# Altium schematic sheet LEDBoard.SchDoc(LEDBoard)
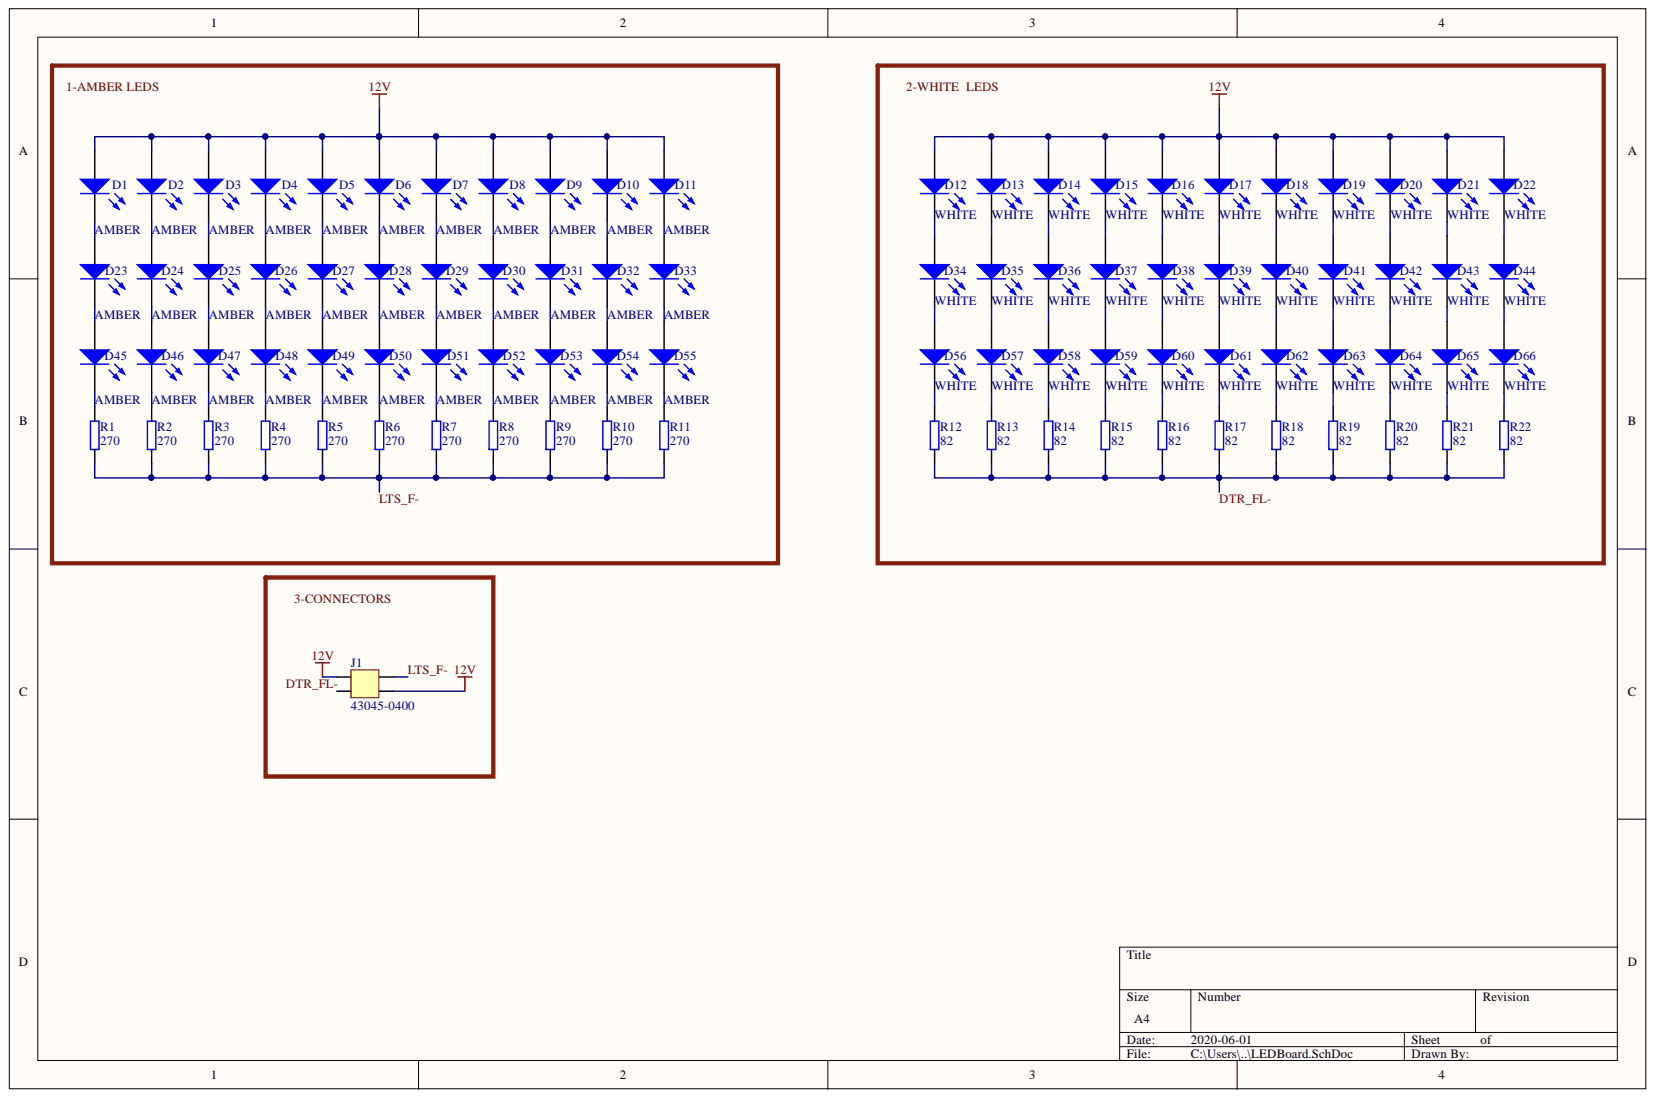
[diagram p0: LEDBoard.SchDoc]

## Parts
D1  AMBER  [XPCAMB-L1-0000-00501]
D2  AMBER  [XPCAMB-L1-0000-00501]
D3  AMBER  [XPCAMB-L1-0000-00501]
D4  AMBER  [XPCAMB-L1-0000-00501]
D5  AMBER  [XPCAMB-L1-0000-00501]
D6  AMBER  [XPCAMB-L1-0000-00501]
D7  AMBER  [XPCAMB-L1-0000-00501]
D8  AMBER  [XPCAMB-L1-0000-00501]
D9  AMBER  [XPCAMB-L1-0000-00501]
D10  AMBER  [XPCAMB-L1-0000-00501]
D11  AMBER  [XPCAMB-L1-0000-00501]
D12  WHITE  [XPCAMB-L1-0000-00501]
D13  WHITE  [XPCAMB-L1-0000-00501]
D14  WHITE  [XPCAMB-L1-0000-00501]
D15  WHITE  [XPCAMB-L1-0000-00501]
D16  WHITE  [XPCAMB-L1-0000-00501]
D17  WHITE  [XPCAMB-L1-0000-00501]
D18  WHITE  [XPCAMB-L1-0000-00501]
D19  WHITE  [XPCAMB-L1-0000-00501]
D20  WHITE  [XPCAMB-L1-0000-00501]
D21  WHITE  [XPCAMB-L1-0000-00501]
D22  WHITE  [XPCAMB-L1-0000-00501]
D23  AMBER  [XPCAMB-L1-0000-00501]
D24  AMBER  [XPCAMB-L1-0000-00501]
D25  AMBER  [XPCAMB-L1-0000-00501]
D26  AMBER  [XPCAMB-L1-0000-00501]
D27  AMBER  [XPCAMB-L1-0000-00501]
D28  AMBER  [XPCAMB-L1-0000-00501]
D29  AMBER  [XPCAMB-L1-0000-00501]
D30  AMBER  [XPCAMB-L1-0000-00501]
D31  AMBER  [XPCAMB-L1-0000-00501]
D32  AMBER  [XPCAMB-L1-0000-00501]
D33  AMBER  [XPCAMB-L1-0000-00501]
D34  WHITE  [XPCAMB-L1-0000-00501]
D35  WHITE  [XPCAMB-L1-0000-00501]
D36  WHITE  [XPCAMB-L1-0000-00501]
D37  WHITE  [XPCAMB-L1-0000-00501]
D38  WHITE  [XPCAMB-L1-0000-00501]
D39  WHITE  [XPCAMB-L1-0000-00501]
D40  WHITE  [XPCAMB-L1-0000-00501]
D41  WHITE  [XPCAMB-L1-0000-00501]
D42  WHITE  [XPCAMB-L1-0000-00501]
D43  WHITE  [XPCAMB-L1-0000-00501]
D44  WHITE  [XPCAMB-L1-0000-00501]
D45  AMBER  [XPCAMB-L1-0000-00501]
D46  AMBER  [XPCAMB-L1-0000-00501]
D47  AMBER  [XPCAMB-L1-0000-00501]
D48  AMBER  [XPCAMB-L1-0000-00501]
D49  AMBER  [XPCAMB-L1-0000-00501]
D50  AMBER  [XPCAMB-L1-0000-00501]
D51  AMBER  [XPCAMB-L1-0000-00501]
D52  AMBER  [XPCAMB-L1-0000-00501]
D53  AMBER  [XPCAMB-L1-0000-00501]
D54  AMBER  [XPCAMB-L1-0000-00501]
D55  AMBER  [XPCAMB-L1-0000-00501]
D56  WHITE  [XPCAMB-L1-0000-00501]
D57  WHITE  [XPCAMB-L1-0000-00501]
D58  WHITE  [XPCAMB-L1-0000-00501]
D59  WHITE  [XPCAMB-L1-0000-00501]
D60  WHITE  [XPCAMB-L1-0000-00501]
D61  WHITE  [XPCAMB-L1-0000-00501]
D62  WHITE  [XPCAMB-L1-0000-00501]
D63  WHITE  [XPCAMB-L1-0000-00501]
D64  WHITE  [XPCAMB-L1-0000-00501]
D65  WHITE  [XPCAMB-L1-0000-00501]
D66  WHITE  [XPCAMB-L1-0000-00501]
J1  43045-0400  [43045-0400]
R1  270  [0805]
R2  270  [0805]
R3  270  [0805]
R4  270  [0805]
R5  270  [0805]
R6  270  [0805]
R7  270  [0805]
R8  270  [0805]
R9  270  [0805]
R10  270  [0805]
R11  270  [0805]
R12  82  [0805]
R13  82  [0805]
R14  82  [0805]
R15  82  [0805]
R16  82  [0805]
R17  82  [0805]
R18  82  [0805]
R19  82  [0805]
R20  82  [0805]
R21  82  [0805]
R22  82  [0805]

## Nets
12V: D1.1 D2.1 D3.1 D4.1 D5.1 D6.1 D7.1 D8.1 D9.1 D10.1 D11.1 D12.1 D13.1 D14.1 D15.1 D16.1 D17.1 D18.1 D19.1 D20.1 D21.1 D22.1 J1.1 J1.4
DTR_FL-: J1.2 R12.1 R13.1 R14.1 R15.1 R16.1 R17.1 R18.1 R19.1 R20.1 R21.1 R22.1
LTS_F-: J1.3 R1.1 R2.1 R3.1 R4.1 R5.1 R6.1 R7.1 R8.1 R9.1 R10.1 R11.1
NetD1_2: D1.2 D23.1
NetD2_2: D2.2 D24.1
NetD3_2: D3.2 D25.1
NetD4_2: D4.2 D26.1
NetD5_2: D5.2 D27.1
NetD6_2: D6.2 D28.1
NetD7_2: D7.2 D29.1
NetD8_2: D8.2 D30.1
NetD9_2: D9.2 D31.1
NetD10_2: D10.2 D32.1
NetD11_2: D11.2 D33.1
NetD12_2: D12.2 D34.1
NetD13_2: D13.2 D35.1
NetD14_2: D14.2 D36.1
NetD15_2: D15.2 D37.1
NetD16_2: D16.2 D38.1
NetD17_2: D17.2 D39.1
NetD18_2: D18.2 D40.1
NetD19_2: D19.2 D41.1
NetD20_2: D20.2 D42.1
NetD21_2: D21.2 D43.1
NetD22_2: D22.2 D44.1
NetD23_2: D23.2 D45.1
NetD24_2: D24.2 D46.1
NetD25_2: D25.2 D47.1
NetD26_2: D26.2 D48.1
NetD27_2: D27.2 D49.1
NetD28_2: D28.2 D50.1
NetD29_2: D29.2 D51.1
NetD30_2: D30.2 D52.1
NetD31_2: D31.2 D53.1
NetD32_2: D32.2 D54.1
NetD33_2: D33.2 D55.1
NetD34_2: D34.2 D56.1
NetD35_2: D35.2 D57.1
NetD36_2: D36.2 D58.1
NetD37_2: D37.2 D59.1
NetD38_2: D38.2 D60.1
NetD39_2: D39.2 D61.1
NetD40_2: D40.2 D62.1
NetD41_2: D41.2 D63.1
NetD42_2: D42.2 D64.1
NetD43_2: D43.2 D65.1
NetD44_2: D44.2 D66.1
NetD45_2: D45.2 R1.2
NetD46_2: D46.2 R2.2
NetD47_2: D47.2 R3.2
NetD48_2: D48.2 R4.2
NetD49_2: D49.2 R5.2
NetD50_2: D50.2 R6.2
NetD51_2: D51.2 R7.2
NetD52_2: D52.2 R8.2
NetD53_2: D53.2 R9.2
NetD54_2: D54.2 R10.2
NetD55_2: D55.2 R11.2
NetD56_2: D56.2 R12.2
NetD57_2: D57.2 R13.2
NetD58_2: D58.2 R14.2
NetD59_2: D59.2 R15.2
NetD60_2: D60.2 R16.2
NetD61_2: D61.2 R17.2
NetD62_2: D62.2 R18.2
NetD63_2: D63.2 R19.2
NetD64_2: D64.2 R20.2
NetD65_2: D65.2 R21.2
NetD66_2: D66.2 R22.2

## Library data of the parts
D1-D11 D23-D33 D45-D55 = XPCAMB-L1-0000-00501: LED Lighting Color XLamp® XP-C Amber 590nm (585nm ~ 595nm) 1414 (3535 Metric); Manufacturer 1: Cree; Viewing Angle: 125°; Thermal Resistance of Package: 15°C/W; Test Temperature: 25°C; Test Current: 350mA; Reverse Voltage: 5V; Power Rating: 2W; Number of Elements: 1; Luminous Flux: 56.8lm; Lens Color: Clear; Illumination Color: Orange; Forward Voltage: 2.2V; Width: 3.45mm; Wavelength: 595nm; Supplier Part Number 1: 82T7198; Supplier 1: Newark; Stock 1: 0; RoHS: Yes; Pricing 1: 1000=1.81000, 3000=1.71000, 6000=1.62000, 12000=1.46000, 18000=1.40000, 30000=1.35000 (CAD); Packaging: Tape and Reel; Package Quantity: 1000; Number of Pins: 2; Mount: Surface Mount; Max Operating Temperature: 150°C; Max Current Rating: 350mA; Manufacturer Part Number 1: XPCAMB-L1-0000-00501; Library Name: LEDBoard.SchLib; Length: 3.45mm; Forward Current: 350mA; Dominant Wavelength: 595nm; Depth: 3.45mm; ComponentLink3URL: https://datasheet.ciiva.com/6348/xlampxpc-6348389.pdf?src-supplier=Digi-Key; ComponentLink3Description: https://datasheet.ciiva.com/6348/xlampxpc-6348389.pdf?src-supplier=Digi-Key; ComponentLink2URL: https://www.cree.com/led-components/media/documents/XLampXPC.pdf; ComponentLink2Description: Datasheet; ComponentLink1URL: https://www.digikey.com/product-detail/en/cree-inc/XPCAMB-L1-0000-00501/XPCAMB-L1-0000-00501-ND/3747321; ComponentLink1Description: Digikey; Component Kind: Standard; Color: Orange; Case/Package: SMD/SMT
D12-D22 D34-D44 D56-D66 = XPEBTT-01-0000-00T80: LED Lighting Xlamp® XP-E Torch White, Cool 6000K ~ 10500K 3.5V 1.05A 125° 1414 (3535 Metric); Manufacturer 1: Cree; Thermal Resistance of Package: 9°C/W; Max Current Rating: 1.5A; Viewing Angle: 125°; Test Current: 1.05A; Supplier Part Number 1: XPEBTT-01-0000-00T80CT-ND; Supplier 1: Digi-Key; Stock 1: 387; RoHS: Yes; Pricing 1: 1=0.69000, 10=0.65200, 50=0.63400, 100=0.58380, 200=0.53090, 500=0.49724 (USD); Packaging: Tape and Reel; Mount: Surface Mount; Manufacturer Part Number 1: XPEBTT-01-0000-00T80; Library Name: LEDBoard.SchLib; Lead Free: Lead Free; Forward Voltage: 3.5V; Correlated Color Temperature (CCT): 6000K ~ 10500K; ComponentLink4URL: http://www.cree.com/led-components/media/documents/ds-XPE2-Torch.pdf?src-supplier=Digi-Key; ComponentLink4Description: http://www.cree.com/led-components/media/documents/ds-XPE2-Torch.pdf?src-supplier=Digi-Key; ComponentLink3URL: http://www.mouser.com/ds/2/90/ds%20XPE2%20Torch-519361.pdf?src-supplier=Mouser; ComponentLink3Description: http://www.mouser.com/ds/2/90/ds%20XPE2%20Torch-519361.pdf?src-supplier=Mouser; ComponentLink2URL: https://www.digikey.com/product-detail/en/cree-inc/XPEBTT-01-0000-00T80/XPEBTT-01-0000-00T80CT-ND/5806157; ComponentLink2Description: Digikey; ComponentLink1URL: https://www.cree.com/led-components/media/documents/ds-XPE2-Torch.pdf; ComponentLink1Description: Datasheet; Component Kind: Standard; Color: White; Case/Package: SMD/SMT
J1 = 43045-0400: Micro-fit 3.0 4pos right-angle; Manufacturer: Molex; Row Spacing: 0.118inch; Pitch: 3mm; Number of Positions: 4; Max Voltage Rating (AC): 250V; Contact Resistance: 10mR; Connector Type: Male Pin; Termination: Solder; Supplier Part Number 1: WM1814-ND; Supplier 1: Digi-Key; Stock 1: 27642; RoHS: Yes; Packaging: Tray; Orientation: Right Angle; Number of Rows: 2; Number of Contacts: 4; Mount: Through Hole; Min Operating Temperature: -40°C; Max Operating Temperature: 105°C; Manufacturer Part Number: 43045-0400; Library Name: Connectors.SchLib; Length: 10.15mm; Housing Material: Polymer; Housing Color: Black; Flammability Rating: UL94 V-0; Fastening Type: Ramp; Depth: 12.2mm; Contact Plating: Tin; Contact Material: Brass; ComponentLink4URL: https://datasheet.ciiva.com/11746/0900766b80027348-11746988.pdf?src-supplier=RSComponents; ComponentLink4Description: https://datasheet.ciiva.com/11746/0900766b80027348-11746988.pdf?src-supplier=RSComponents; ComponentLink3URL: https://datasheet.ciiva.com/30193/145420430450400-pcb-headers-30193310.pdf?src-supplier=Onlinecomponents.com; ComponentLink3Description: https://datasheet.ciiva.com/30193/145420430450400-pcb-headers-30193310.pdf?src-supplier=Onlinecomponents.com; ComponentLink2URL: https://datasheet.ciiva.com/20209/22-3744e-20209522.pdf?src-supplier=Rapid+Electronics; ComponentLink2Description: https://datasheet.ciiva.com/20209/22-3744e-20209522.pdf?src-supplier=Rapid+Electronics; ComponentLink1URL: https://datasheet.ciiva.com/712/430450200-sd-712017.pdf?src-supplier=Digi-Key; ComponentLink1Description: https://datasheet.ciiva.com/712/430450200-sd-712017.pdf?src-supplier=Digi-Key; Component Kind: Standard; Color: Black; Category: Headers and Wire Housings
R1-R11 = RMCF0805JT270R: 270 Ohms ±5% 0.125W, 1/8W Chip Resistor 0805 (2012 Metric) Automotive AEC-Q200 Thick Film; Manufacturer 1: Stackpole Electronics; Temperature Coefficient: 200ppm/°C; Resistance: 270R; Power Rating: 125mW; Width: 0.049inch; Tolerance: 5%; Supplier Part Number 1: RMCF0805JT270RCT-ND; Supplier 1: Digi-Key; Stock 1: 134098; RoHS: Yes; Radiation Hardening: No; Pricing 1: 1=0.10000, 10=0.01900, 100=0.00780, 1000=0.00350, 2500=0.00304 (USD); Packaging: Tape and Reel; Number of Terminations: 2; Mount: Surface Mount; Min Operating Temperature: -55°C; Military Standard: Not; Max Power Dissipation: 125mW; Max Operating Temperature: 155°C; Manufacturer Part Number 1: RMCF0805JT270R; Library Name: LEDBoard.SchLib; Length: 0.079inch; Lead Free: Lead Free; Height: 0.026inch; Features: Automotive AEC-Q200; Depth: 1.25mm; Contact Plating: Tin,Matte; Composition: Thick Film; ComponentLink3URL: https://datasheet.ciiva.com/26919/getdatasheetpartid-464226-26919549.pdf?src-supplier=Verical; ComponentLink3Description: https://datasheet.ciiva.com/26919/getdatasheetpartid-464226-26919549.pdf?src-supplier=Verical; ComponentLink2URL: https://www.seielect.com/catalog/sei-rmcf_rmcp.pdf; ComponentLink2Description: Datasheet; ComponentLink1URL: https://www.digikey.com/product-detail/en/stackpole-electronics-inc/RMCF0805JT270R/RMCF0805JT270RCT-ND/1942546; ComponentLink1Description: Digikey; Component Kind: Standard; Case Code (Metric): 2012; Case Code (Imperial): 0805; Case/Package: 0805
R12-R22 = RK73H2ATTD82R0F: 82 Ohms ±1% 0.25W, 1/4W Chip Resistor 0805 (2012 Metric) Automotive AEC-Q200, Moisture Resistant Thick Film; Manufacturer 1: KOA Speer; Voltage Rating: 150V; Temperature Coefficient: 100ppm/°C; Resistance: 82R; Power Rating: 125mW; Width: 1.25mm; Tolerance: 1%; Termination: SMD/SMT; Supplier Part Number 1: 2019-RK73H2ATTD82R0FCT-ND; Supplier 1: Digi-Key; Stock 1: 62113; RoHS: Yes; Pricing 1: 1=0.10000, 10=0.03300, 100=0.01360, 1000=0.00612, 2500=0.00531 (USD); Packaging: Tape and Reel; Package Quantity: 5000; Number of Pins: 2; Min Operating Temperature: -55°C; Military Standard: Not; Max Operating Temperature: 155°C; Manufacturer Part Number 1: RK73H2ATTD82R0F; Library Name: LEDBoard.SchLib; Length: 2mm; Lead Free: Lead Free; Height: 0.5mm; Depth: 1.25mm; Composition: Thick Film; ComponentLink3URL: https://datasheet.ciiva.com/5553/rk73h-5553054.pdf?src-supplier=Mouser; ComponentLink3Description: https://datasheet.ciiva.com/5553/rk73h-5553054.pdf?src-supplier=Mouser; ComponentLink2URL: http://www.koaspeer.com/pdfs/RK73H.pdf; ComponentLink2Description: Datasheet; ComponentLink1URL: https://www.digikey.com/product-detail/en/koa-speer-electronics-inc/RK73H2ATTD82R0F/2019-RK73H2ATTD82R0FCT-ND/10235651; ComponentLink1Description: Digikey; Component Kind: Standard; Case Code (Metric): 2012; Case Code (Imperial): 0805; Case/Package: 0805
